# Altium schematic sheet MCU_MSP430F5529IPN.SchDoc(MCU_MSP430F5529IPN)
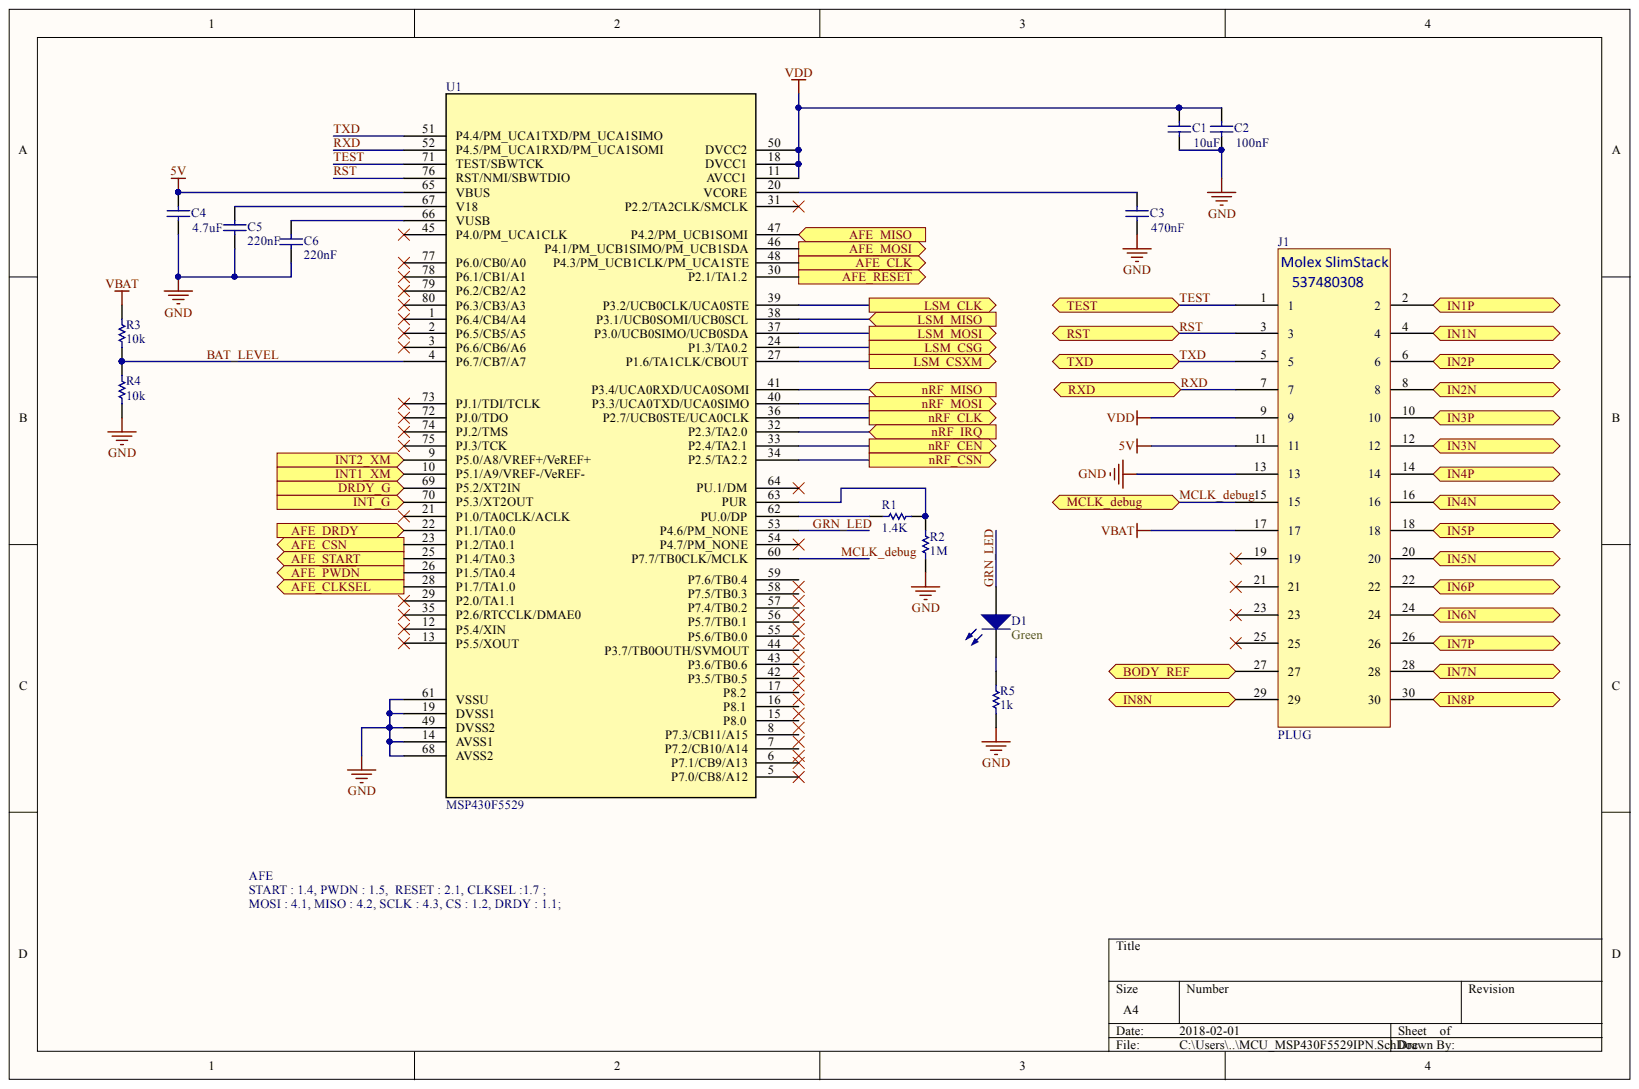
[diagram p0: MCU_MSP430F5529IPN.SchDoc]

## Parts
C1  value 10uF  [0402]  (hidden comment: Cap Semi)
C2  value 100nF  [0402]  (hidden comment: Cap Semi)
C3  value 470nF  [0402]  (hidden comment: Cap Semi)
C4  value 4.7uF  [0402]  (hidden comment: Cap Semi)
C5  value 220nF  [0402]  (hidden comment: Cap Semi)
C6  value 220nF  [0402]  (hidden comment: Cap Semi)
D1  Color: Green  [0402]
J1  PLUG  [Molex 0529910308]
R1  value 1.4K  [0402]
R2  value 1M  [0402]
R3  value 10k  [0402]
R4  value 10k  [0402]
R5  value 1k  [0402]
U1  MSP430F5529  [80-LQFP]

## Ports
AFE_CLK, AFE_CLKSEL, AFE_CSN, AFE_DRDY, AFE_MISO, AFE_MOSI, AFE_START, AFE_~{PWDN}, AFE~{_RESET}, BODY_REF, DRDY_G, IN1N, IN1P, IN2N, IN2P, IN3N, IN3P, IN4N, IN4P, IN5N, IN5P, IN6N, IN6P, IN7N, IN7P, IN8N, IN8P, INT1_XM, INT2_XM, INT_G, LSM_CLK, LSM_CSG, LSM_CSXM, LSM_MISO, LSM_MOSI, MCLK_DEBUG, NRF_CEN, NRF_CLK, NRF_CSN, NRF_IRQ, NRF_MISO, NRF_MOSI, RST, RXD, TEST, TXD

## Nets
5V: C4.1 J1.11 U1.65(VBUS)
GND: C1.2 C2.2 C3.2 C4.2 C5.1 C6.1 J1.13 R2.2 R4.1 R5.2 U1.14(AVSS1) U1.19(DVSS1) U1.49(DVSS2) U1.61(VSSU) U1.68(AVSS2)
VBAT: J1.17 R3.2
VDD: C1.1 C2.1 J1.9 U1.11(AVCC1) U1.18(DVCC1) U1.50(DVCC2)
AFE~{_RESET}: U1.30(P2.1/TA1.2) ; port AFE~{_RESET}
AFE_CLK: U1.48(P4.3/PM_UCB1CLK/PM_UCA1STE) ; port AFE_CLK
AFE_CLKSEL: U1.28(P1.7/TA1.0) ; port AFE_CLKSEL
AFE_CSN: U1.23(P1.2/TA0.1) ; port AFE_CSN
AFE_DRDY: U1.22(P1.1/TA0.0) ; port AFE_DRDY
AFE_MISO: U1.47(P4.2/PM_UCB1SOMI) ; port AFE_MISO
AFE_MOSI: U1.46(P4.1/PM_UCB1SIMO/PM_UCB1SDA) ; port AFE_MOSI
AFE_~{PWDN}: U1.26(P1.5/TA0.4) ; port AFE_~{PWDN}
AFE_START: U1.25(P1.4/TA0.3) ; port AFE_START
BAT_LEVEL: R3.1 R4.2 U1.4(P6.7/CB7/A7)
BODY_REF: J1.27 ; port BODY_REF
DRDY_G: U1.69(P5.2/XT2IN) ; port DRDY_G
GRN_LED: D1.1 U1.53(P4.6/PM_NONE)
IN1N: J1.4 ; port IN1N
IN1P: J1.2 ; port IN1P
IN2N: J1.8 ; port IN2N
IN2P: J1.6 ; port IN2P
IN3N: J1.12 ; port IN3N
IN3P: J1.10 ; port IN3P
IN4N: J1.16 ; port IN4N
IN4P: J1.14 ; port IN4P
IN5N: J1.20 ; port IN5N
IN5P: J1.18 ; port IN5P
IN6N: J1.24 ; port IN6N
IN6P: J1.22 ; port IN6P
IN7N: J1.28 ; port IN7N
IN7P: J1.26 ; port IN7P
IN8N: J1.29 ; port IN8N
IN8P: J1.30 ; port IN8P
INT1_XM: U1.10(P5.1/A9/VREF-/VeREF-) ; port INT1_XM
INT2_XM: U1.9(P5.0/A8/VREF+/VeREF+) ; port INT2_XM
INT_G: U1.70(P5.3/XT2OUT) ; port INT_G
LSM_CLK: U1.39(P3.2/UCB0CLK/UCA0STE) ; port LSM_CLK
LSM_CSG: U1.24(P1.3/TA0.2) ; port LSM_CSG
LSM_CSXM: U1.27(P1.6/TA1CLK/CBOUT) ; port LSM_CSXM
LSM_MISO: U1.38(P3.1/UCB0SOMI/UCB0SCL) ; port LSM_MISO
LSM_MOSI: U1.37(P3.0/UCB0SIMO/UCB0SDA) ; port LSM_MOSI
MCLK_DEBUG: J1.15 U1.60(P7.7/TB0CLK/MCLK) ; port MCLK_DEBUG
RST: J1.3 U1.76(RST/NMI/SBWTDIO) ; port RST
RXD: J1.7 U1.52(P4.5/PM_UCA1RXD/PM_UCA1SOMI) ; port RXD
TEST: J1.1 U1.71(TEST/SBWTCK) ; port TEST
TXD: J1.5 U1.51(P4.4/PM_UCA1TXD/PM_UCA1SIMO) ; port TXD
NetC3_1: C3.1 U1.20(VCORE)
NetC5_2: C5.2 U1.67(V18)
NetC6_2: C6.2 U1.66(VUSB)
NetD1_2: D1.2 R5.1
NetR1_1: R1.1 R2.1 U1.63(PUR)
NetR1_2: R1.2 U1.62(PU.0/DP)
NetU1_32: U1.32(P2.3/TA2.0) ; port NRF_IRQ
NetU1_33: U1.33(P2.4/TA2.1) ; port NRF_CEN
NetU1_34: U1.34(P2.5/TA2.2) ; port NRF_CSN
NetU1_36: U1.36(P2.7/UCB0STE/UCA0CLK) ; port NRF_CLK
NetU1_40: U1.40(P3.3/UCA0TXD/UCA0SIMO) ; port NRF_MOSI
NetU1_41: U1.41(P3.4/UCA0RXD/UCA0SOMI) ; port NRF_MISO

Not connected: J1 19 21 23 25; U1 1(P6.4/CB4/A4) 2(P6.5/CB5/A5) 3(P6.6/CB6/A6) 5(P7.0/CB8/A12) 6(P7.1/CB9/A13) 7(P7.2/CB10/A14) 8(P7.3/CB11/A15) 12(P5.4/XIN) 13(P5.5/XOUT) 15(P8.0) 16(P8.1) 17(P8.2) 21(P1.0/TA0CLK/ACLK) 29(P2.0/TA1.1) 31(P2.2/TA2CLK/SMCLK) 35(P2.6/RTCCLK/DMAE0) 42(P3.5/TB0.5) 43(P3.6/TB0.6) 44(P3.7/TB0OUTH/SVMOUT) 45(P4.0/PM_UCA1CLK) 54(P4.7/PM_NONE) 55(P5.6/TB0.0) 56(P5.7/TB0.1) 57(P7.4/TB0.2) 58(P7.5/TB0.3) 59(P7.6/TB0.4) 64(PU.1/DM) 72(PJ.0/TDO) 73(PJ.1/TDI/TCLK) 74(PJ.2/TMS) 75(PJ.3/TCK) 77(P6.0/CB0/A0) 78(P6.1/CB1/A1) 79(P6.2/CB2/A2) 80(P6.3/CB3/A3)

## Library data of the parts
C1-C6 = Cap Semi: Capacitor (Semiconductor SIM Model); Component Kind: Standard; LatestRevisionDate: 29-May-2009; LatestRevisionNote: IPC-7351 Footprint Added.; Library Name: Miscellaneous Devices.IntLib; PackageDescription: Chip Capacitor; PackageReference: C1206; Published: 8-Jun-2000; Signal Integrity: Cap Semi; Simulation: CAP; Supplier 1: Digi-Key; Publisher: Altium Limited
  C1 C2: Supplier Part Number 1: 490-10692-2-ND
  C3: Supplier Part Number 1: 1276-1734-2-ND
  C4: Supplier Part Number 1: 490-5915-2-ND
  C5 C6: Supplier Part Number 1: 490-3884-2-ND
D1 = LED3: Typical BLUE SiC LED; Component Kind: Standard; DatasheetVersion: 16-Apr-2002; LatestRevisionDate: 17-Dec-2003; LatestRevisionNote: PCB Footprint replaced.; Library Name: Miscellaneous Devices.IntLib; PackageDescription: SM LED; 2 C-Bend Leads; Body 3.5 x 2.8 x 1.9 mm, inc leads (LxWxH typ); PackageReference: 3.5X2.8X1.9; PackageVersion: 16-Apr-2002; Published: 8-Jun-2000; Signal Integrity: LED; Simulation: LED3; Publisher: Altium Limited
J1 = Molex 529910308: Component Kind: Standard; Library Name: Molex_0537480308_PLUG.SchLib
R1-R5 = Res Semi: Semiconductor Resistor; Component Kind: Standard; LatestRevisionDate: 17-Jul-2002; LatestRevisionNote: Re-released for DXP Platform.; Library Name: Miscellaneous Devices.IntLib; PackageDescription: Axial Device, Thru-Hole; 2 Leads; 0.5 in Pin Spacing; PackageReference: AXIAL-0.5; Published: 8-Jun-2000; Signal Integrity: Res Semi; Simulation: RES; Supplier 1: Digi-Key; Publisher: Altium Limited
  R1 R3 R4: Supplier Part Number 1: RHM1.4KBHTR-ND
  R2: Supplier Part Number 1: RHM1.00MCDTR-ND
  R5: Supplier Part Number 1: RHM100CDTR-ND
U1 = MSP430F5529: IC MCU 16BIT 128KB FLASH 80LQFP; Component Kind: Standard; Library Name: MSP430F5529IPN.SchLib; Supplier 1: Digi-Key; Supplier Part Number 1: 296-25427-ND
